# Altium schematic sheet adc.SchDoc
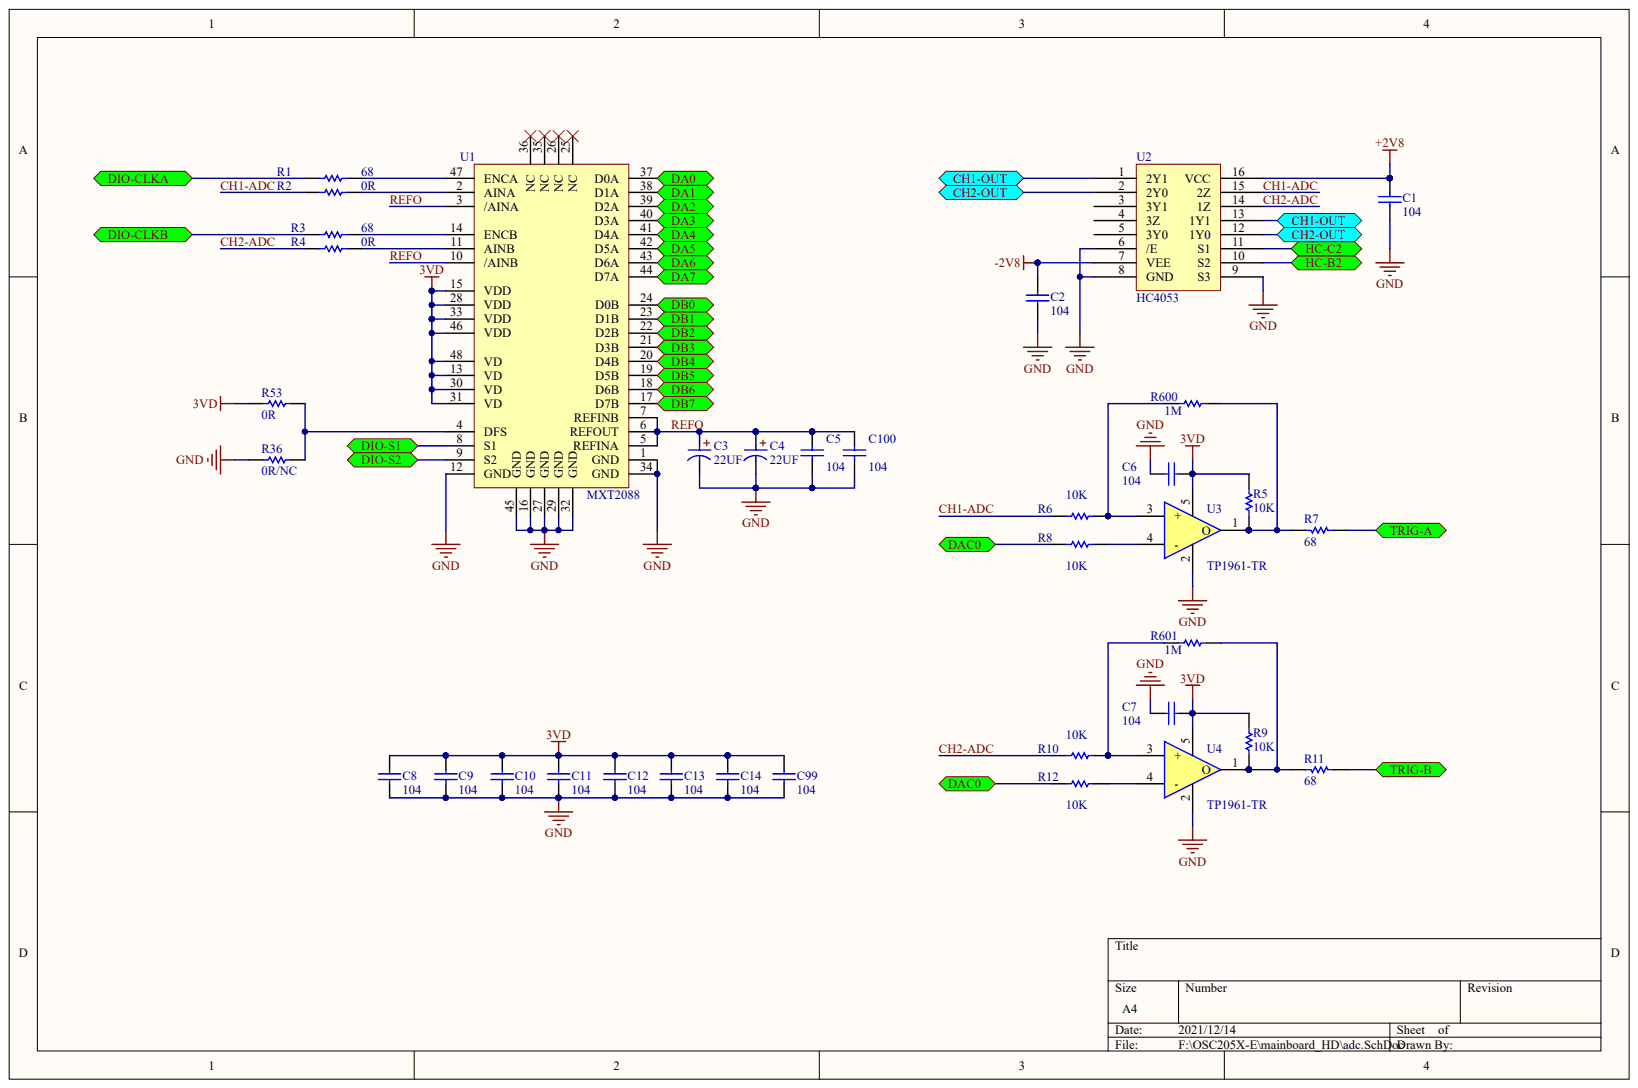
[diagram p0: adc.SchDoc]

## Parts
C1  C104  (value not drawn)
C2  C104  (value not drawn)
C3  22UF
C4  22UF
C5  C104  (value not drawn)
C6  C104  (value not drawn)
C7  C104  (value not drawn)
C8  C104  (value not drawn)
C9  C104  (value not drawn)
C10  C104  (value not drawn)
C11  C104  (value not drawn)
C12  C104  (value not drawn)
C13  C104  (value not drawn)
C14  C104  (value not drawn)
C99  C104  (value not drawn)
C100  C104  (value not drawn)
R1  68
R2  0R
R3  68
R4  0R
R5  10K
R6  10K
R7  68
R8  10K
R9  10K
R10  10K
R11  68
R12  10K
R36  0R/NC
R53  0R
R600  1M
R601  1M
U1  MXT2088
U2  HC4053
U3  TP1961-TR
U4  TP1961-TR

## Ports
CH1-OUT, CH2-OUT, DA0, DA1, DA2, DA3, DA4, DA5, DA6, DA7, DAC0, DB0, DB1, DB2, DB3, DB4, DB5, DB6, DB7, DIO-CLKA, DIO-CLKB, DIO-S1, DIO-S2, HC-B2, HC-C2, TRIG-A, TRIG-B

## Nets
3VD: C6.1 C7.1 C8.1 C9.1 C10.1 C11.1 C12.1 C13.1 C14.1 C99.1 R5.2 R9.2 R53.1 U1.13(VD) U1.15(VDD) U1.28(VDD) U1.30(VD) U1.31(VD) U1.33(VDD) U1.46(VDD) U1.48(VD) U3.5 U4.5
+2V8: C1.1 U2.16(VCC)
-2V8: C2.1 U2.7(VEE)
GND: C1.2 C2.2 C3.2 C4.2 C5.2 C6.2 C7.2 C8.2 C9.2 C10.2 C11.2 C12.2 C13.2 C14.2 C99.2 C100.2 R36.1 U1.1(GND) U1.12(GND) U1.16(GND) U1.27(GND) U1.29(GND) U1.32(GND) U1.34(GND) U1.45(GND) U2.6(/E) U2.8(GND) U2.9(S3) U3.2 U4.2
CH1-ADC: R2.1 R6.1 U2.15(2Z)
CH1-OUT: U2.1(2Y1) U2.13(1Y1) ; port CH1-OUT
CH2-ADC: R4.1 R10.1 U2.14(1Z)
CH2-OUT: U2.2(2Y0) U2.12(1Y0) ; port CH2-OUT
DA0: U1.37(D0A) ; port DA0
DA1: U1.38(D1A) ; port DA1
DA2: U1.39(D2A) ; port DA2
DA3: U1.40(D3A) ; port DA3
DA4: U1.41(D4A) ; port DA4
DA5: U1.42(D5A) ; port DA5
DA6: U1.43(D6A) ; port DA6
DA7: U1.44(D7A) ; port DA7
DAC0: R8.1 R12.1 ; port DAC0
DB0: U1.24(D0B) ; port DB0
DB1: U1.23(D1B) ; port DB1
DB2: U1.22(D2B) ; port DB2
DB3: U1.21(D3B) ; port DB3
DB4: U1.20(D4B) ; port DB4
DB5: U1.19(D5B) ; port DB5
DB6: U1.18(D6B) ; port DB6
DB7: U1.17(D7B) ; port DB7
DIO-CLKA: R1.1 ; port DIO-CLKA
DIO-CLKB: R3.1 ; port DIO-CLKB
DIO-S1: U1.8(S1) ; port DIO-S1
DIO-S2: U1.9(S2) ; port DIO-S2
HC-B2: U2.10(S2) ; port HC-B2
HC-C2: U2.11(S1) ; port HC-C2
REFO: C3.1 C4.1 C5.1 C100.1 U1.3(/AINA) U1.5(REFINA) U1.6(REFOUT) U1.7(REFINB) U1.10(/AINB)
TRIG-A: R7.1 ; port TRIG-A
TRIG-B: R11.1 ; port TRIG-B
NetR1_2: R1.2 U1.47(ENCA)
NetR2_2: R2.2 U1.2(AINA)
NetR3_2: R3.2 U1.14(ENCB)
NetR4_2: R4.2 U1.11(AINB)
NetR5_1: R5.1 R7.2 R600.1 U3.1(O)
NetR6_2: R6.2 R600.2 U3.3(+)
NetR8_2: R8.2 U3.4(-)
NetR9_1: R9.1 R11.2 R601.1 U4.1(O)
NetR10_2: R10.2 R601.2 U4.3(+)
NetR12_2: R12.2 U4.4(-)
NetR36_2: R36.2 R53.2 U1.4(DFS)

Not connected: U1 25(NC) 26(NC) 35(NC) 36(NC); U2 3(3Y1) 4(3Z) 5(3Y0)

## Library data of the parts
C1-C14 C99 C100 R1-R12 R36 R53 R600 R601 U1-U4 = (no symbol name)
  C1 C2 C5-C14 C99 C100: : 104
  C3 C4: : 22UF
  R1 R3 R7 R11: : 68
  R2 R4 R53: : 0R
  R5 R6 R8-R10 R12: : 10K
  R36: : 0R/NC
  R600 R601: : 1M
